# Altium schematic sheet adc1.SchDoc(adc1)
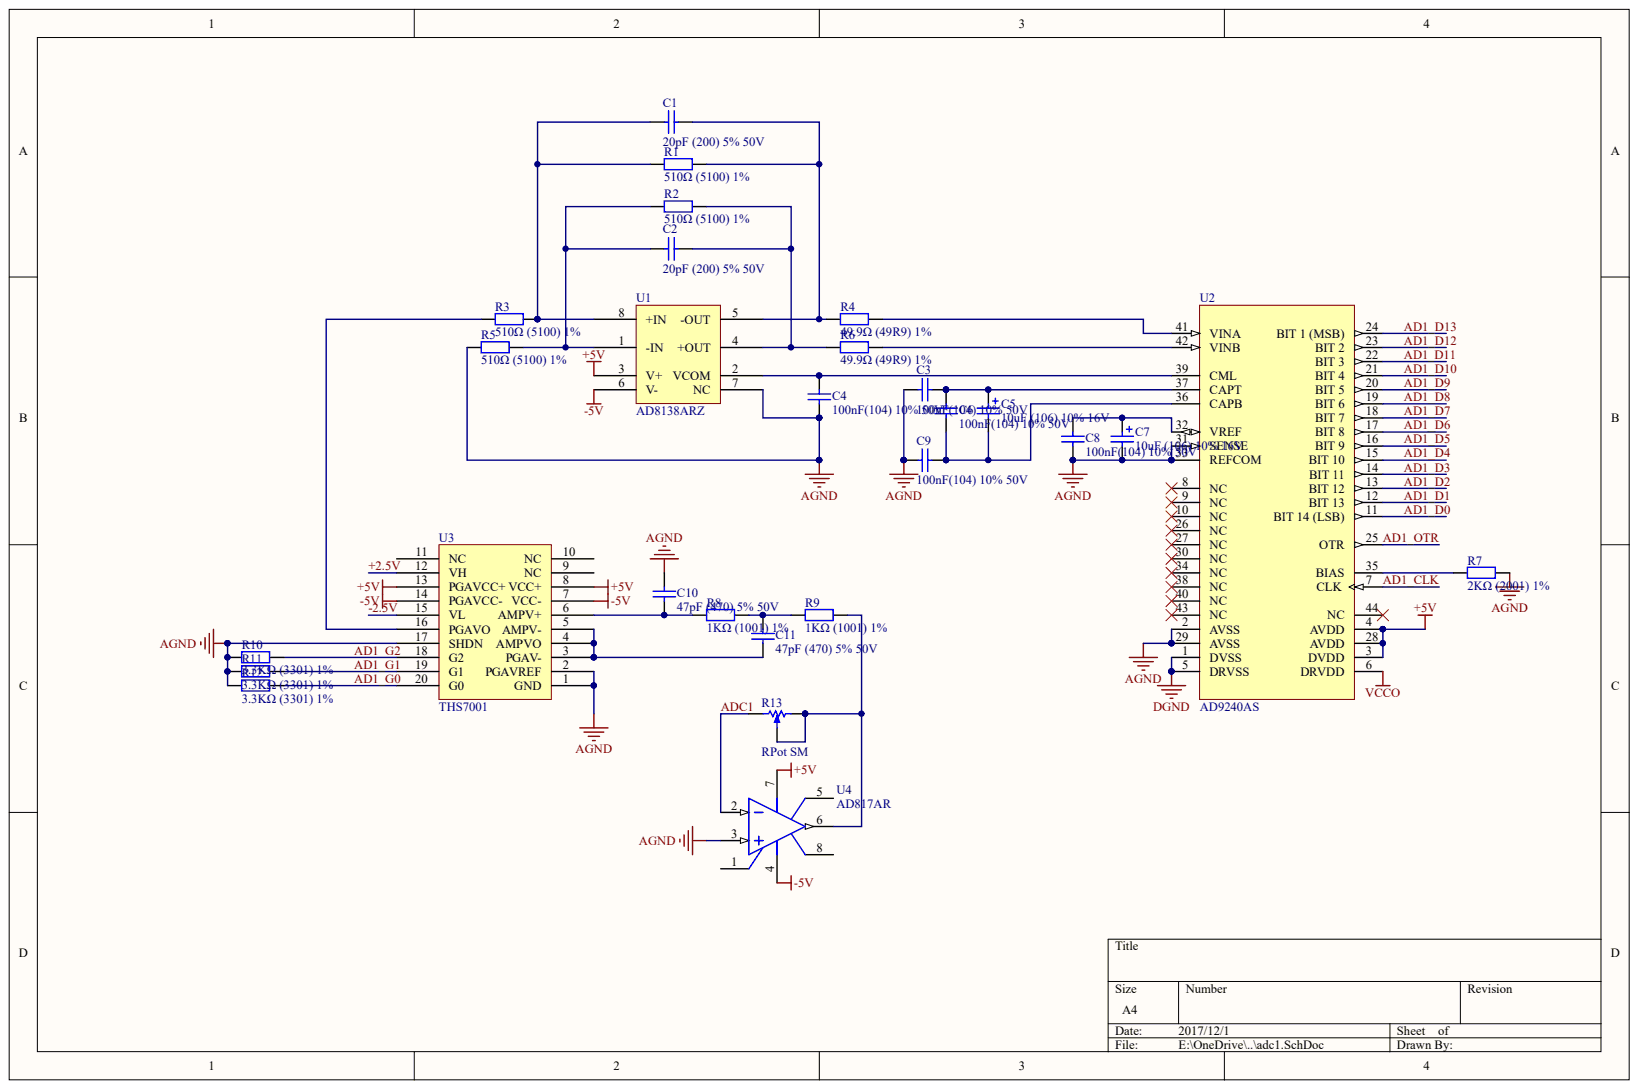
[diagram p0: adc1.SchDoc]

## Parts
C1  20pF (200) 5% 50V
C2  20pF (200) 5% 50V
C3  100nF(104) 10% 50V
C4  100nF(104) 10% 50V
C5  10uF (106) ±10% 16V
C6  100nF(104) 10% 50V
C7  10uF (106) ±10% 16V
C8  100nF(104) 10% 50V
C9  100nF(104) 10% 50V
C10  47pF (470) 5% 50V
C11  47pF (470) 5% 50V
R1  510Ω (5100) ±1%
R2  510Ω (5100) ±1%
R3  510Ω (5100) ±1%
R4  49.9Ω (49R9) ±1%
R5  510Ω (5100) ±1%
R6  49.9Ω (49R9) ±1%
R7  2KΩ (2001) ±1%
R8  1KΩ (1001) ±1%
R9  1KΩ (1001) ±1%
R10  3.3KΩ (3301) ±1%
R11  3.3KΩ (3301) ±1%
R12  3.3KΩ (3301) ±1%
R13  RPot SM
U1  AD8138ARZ
U2  AD9240AS
U3  THS7001
U4  AD817AR

## Nets
+5V: U1.3(V+) U2.3(DVDD) U2.4(AVDD) U2.28(AVDD) U3.8(VCC+) U3.13(PGAVCC+) U4.7
-5V: U1.6(V-) U3.7(VCC-) U3.14(PGAVCC-) U4.4
AGND: C3.2 C4.1 C7.2 C8.1 C9.2 C10.2 R5.2 R7.2 R10.1 R11.1 R12.1 U1.7(NC) U2.2(AVSS) U2.29(AVSS) U2.31(SENSE) U2.33(REFCOM) U3.1(GND) U3.2(PGAVREF) U3.17(SHDN) U4.3
DGND: U2.1(DVSS) U2.5(DRVSS)
VCCO: U2.6(DRVDD)
+2.5V: U3.12(VH)
-2.5V: U3.15(VL)
AD1_CLK: U2.7(CLK)
AD1_D0: U2.11(BIT_14_(LSB))
AD1_D1: U2.12(BIT_13)
AD1_D2: U2.13(BIT_12)
AD1_D3: U2.14(BIT_11)
AD1_D4: U2.15(BIT_10)
AD1_D5: U2.16(BIT_9)
AD1_D6: U2.17(BIT_8)
AD1_D7: U2.18(BIT_7)
AD1_D8: U2.19(BIT_6)
AD1_D9: U2.20(BIT_5)
AD1_D10: U2.21(BIT_4)
AD1_D11: U2.22(BIT_3)
AD1_D12: U2.23(BIT_2)
AD1_D13: U2.24(BIT_1_(MSB))
AD1_G0: R12.2 U3.20(G0)
AD1_G1: R11.2 U3.19(G1)
AD1_G2: R10.2 U3.18(G2)
AD1_OTR: U2.25(OTR)
ADC1: R13.CCW U4.2
NetC1_1: C1.1 R1.1 R4.1 U1.5(-OUT)
NetC1_2: C1.2 R1.2 R3.1 U1.8(+IN)
NetC2_1: C2.1 R2.2 R5.1 U1.1(-IN)
NetC2_2: C2.2 R2.1 R6.1 U1.4(+OUT)
NetC3_1: C3.1 C5.1 C6.2 U2.37(CAPT)
NetC4_2: C4.2 U1.2(VCOM) U2.39(CML)
NetC5_2: C5.2 C6.1 C9.1 U2.36(CAPB)
NetC7_1: C7.1 C8.2 U2.32(VREF)
NetC10_1: C10.1 R8.1 U3.6(AMPV+)
NetC11_1: C11.1 U3.3(PGAV-) U3.4(AMPVO) U3.5(AMPV-)
NetC11_2: C11.2 R8.2 R9.1
NetR3_2: R3.2 U3.16(PGAVO)
NetR4_2: R4.2 U2.41(VINA)
NetR6_2: R6.2 U2.42(VINB)
NetR7_1: R7.1 U2.35(BIAS)
NetR9_2: R9.2 R13.CW R13.W U4.6

Not connected: U2 8(NC) 9(NC) 10(NC) 26(NC) 27(NC) 30(NC) 34(NC) 38(NC) 40(NC) 43(NC) 44(NC); U3 9(NC) 10(NC) 11(NC); U4 1 5 8

## Library data of the parts
U4 = (no symbol name): Note: Pin 5 is not connected.; Published: 8-Jun-2000; LatestRevisionDate: 13-Apr-2006; LatestRevisionNote: IPC-7351 Footprint Added.; DatasheetVersion: REV. B 1995; PackageVersion: revA; PackageReference: SO-8; PackageDescription: 8-Pin Small Outline Integrated Circuit 1.27 mm Pitch; Code_JEDEC: MS-012-AA; Code_IPC: SOIC127P600-8; ComponentLink1Description: Manufacturer Link; ComponentLink1URL: http://www.analog.com/en/prod/0,,759_786_AD817%2C00.html; ComponentLink2Description: Datasheet; ComponentLink2URL: http://www.analog.com/UploadedFiles/Data_Sheets/289066266ad817.pdf; Publisher: Altium Limited
C1-C11 R1-R13 U1-U3 = (no symbol name)
  C1 C2: ComponentLink1Description: 供应商链接; ComponentLink1URL: http://www.szlcsc.com/product/details_2150.html; Package: 0805; Supplier: LC; SuppliersPartNumber: C1798
  C3 C4 C6 C8 C9: ComponentLink1Description: 供应商链接; ComponentLink1URL: http://www.szlcsc.com/product/details_50686.html; Package: 0805; Supplier: LC; SuppliersPartNumber: C49678
  C5 C7: ComponentLink1Description: 供应商链接; ComponentLink1URL: http://www.szlcsc.com/product/details_7643.html; Package: 3216; Supplier: LC; SuppliersPartNumber: C7171
  C10 C11: ComponentLink1Description: 供应商链接; ComponentLink1URL: http://www.szlcsc.com/product/details_15529.html; Package: 0805; Supplier: LC; SuppliersPartNumber: C14857
  R1-R3 R5: ComponentLink1Description: 供应商链接; ComponentLink1URL: http://www.szlcsc.com/product/details_18422.html; Package: 0805; Supplier: LC; SuppliersPartNumber: C17734
  R4 R6: ComponentLink1Description: 供应商链接; ComponentLink1URL: http://www.szlcsc.com/product/details_18408.html; Package: 0805; Supplier: LC; SuppliersPartNumber: C17720
  R7: ComponentLink1Description: 供应商链接; ComponentLink1URL: http://www.szlcsc.com/product/details_18292.html; Package: 0805; Supplier: LC; SuppliersPartNumber: C17604
  R8 R9: ComponentLink1Description: 供应商链接; ComponentLink1URL: http://www.szlcsc.com/product/details_18201.html; Package: 0805; Supplier: LC; SuppliersPartNumber: C17513
  R10-R12: ComponentLink1Description: 供应商链接; ComponentLink1URL: http://www.szlcsc.com/product/details_26753.html; Package: 0805; Supplier: LC; SuppliersPartNumber: C26010
  R13: Published: 17-Dec-2003; DatasheetVersion: Oct-2003; PackageReference: POT4MM-2; PackageDescription: Square Trimming Potentiometer; ComponentLink1Description: Manufacturer Link; ComponentLink1URL: http://bourns.com/2/search.html
  U2: Published: 26-May-2004; LatestRevisionDate: 13-Apr-2006; LatestRevisionNote: IPC-7351 Footprint Added.; DatasheetVersion: Rev. A; PackageVersion: Rev. A; PackageReference: S-44-MQFP; PackageDescription: 44-Pin Metric Quad Flat Pack 0.8 mm Pitch; Code_IPC: QFP80P1320X1320-44; ComponentLink1Description: Manufacturer Link; ComponentLink1URL: http://www.analog.com/en/prod/0%2C2877%2CAD9240%2C00.html; ComponentLink2Description: Datasheet; ComponentLink2URL: http://www.analog.com/UploadedFiles/Data_Sheets/417954540AD9240_a.pdf
  R13 U2: Publisher: Altium Limited
